# Altium schematic sheet Main.SchDoc
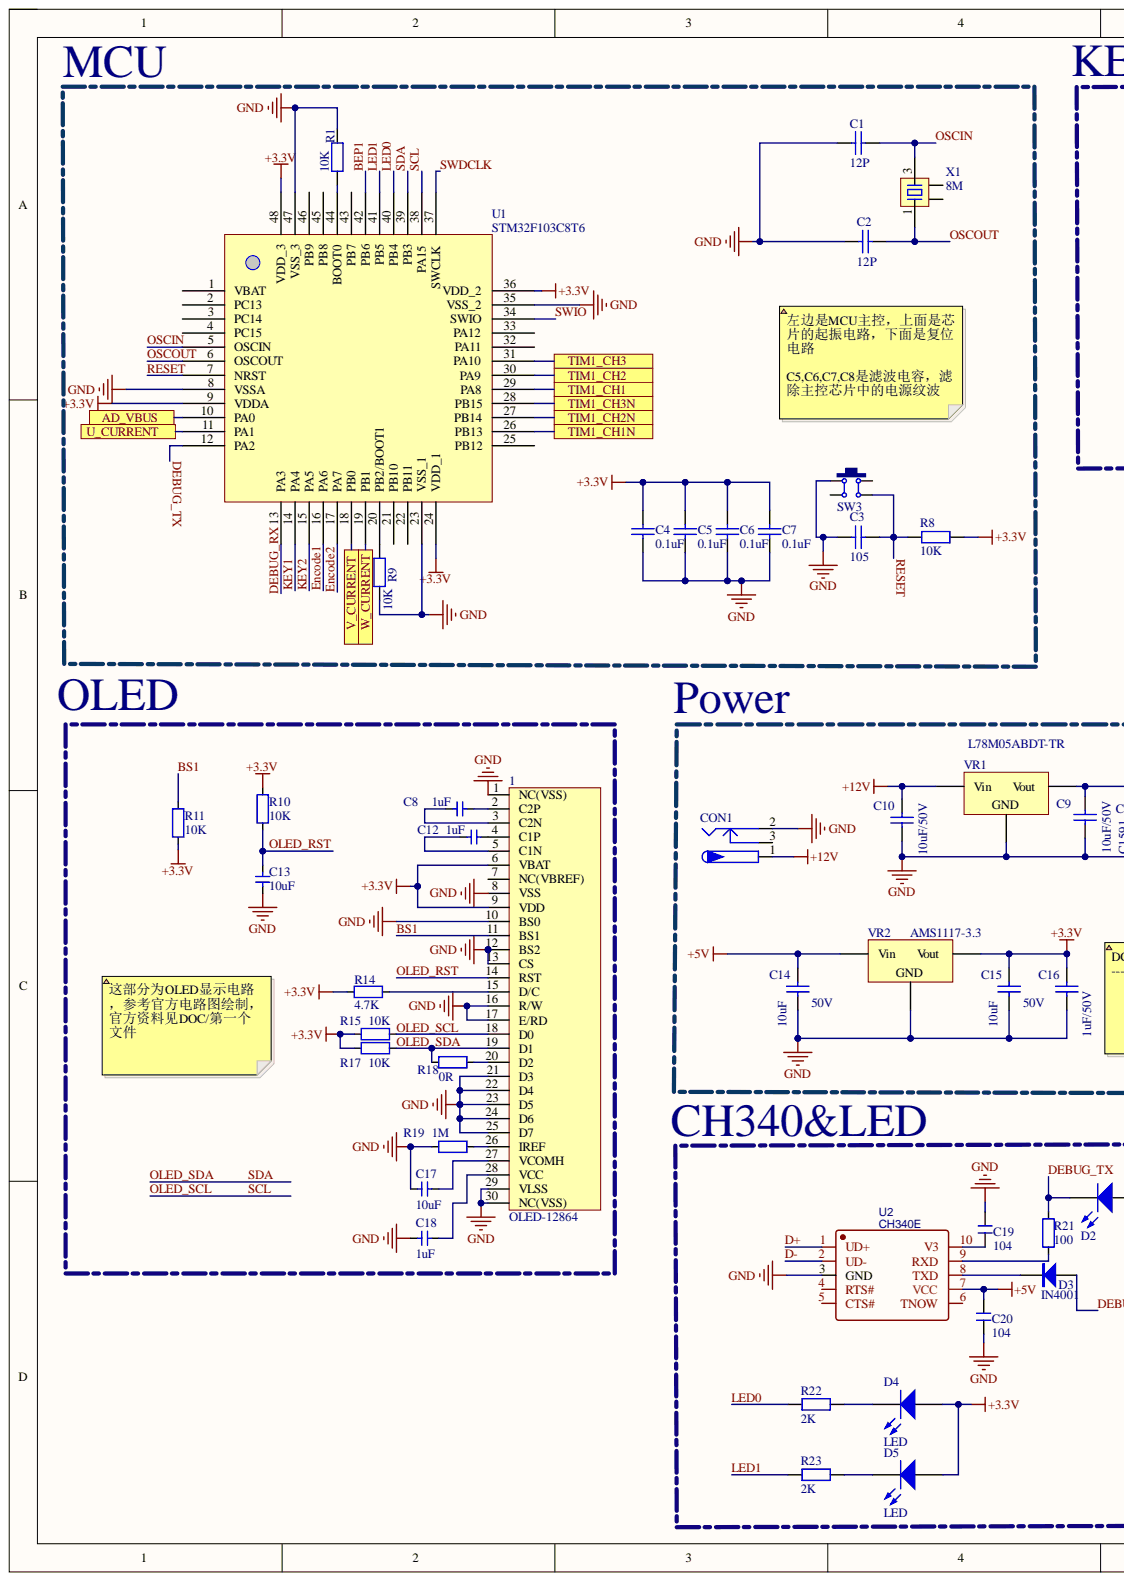
[diagram p0: Main.SchDoc, left]
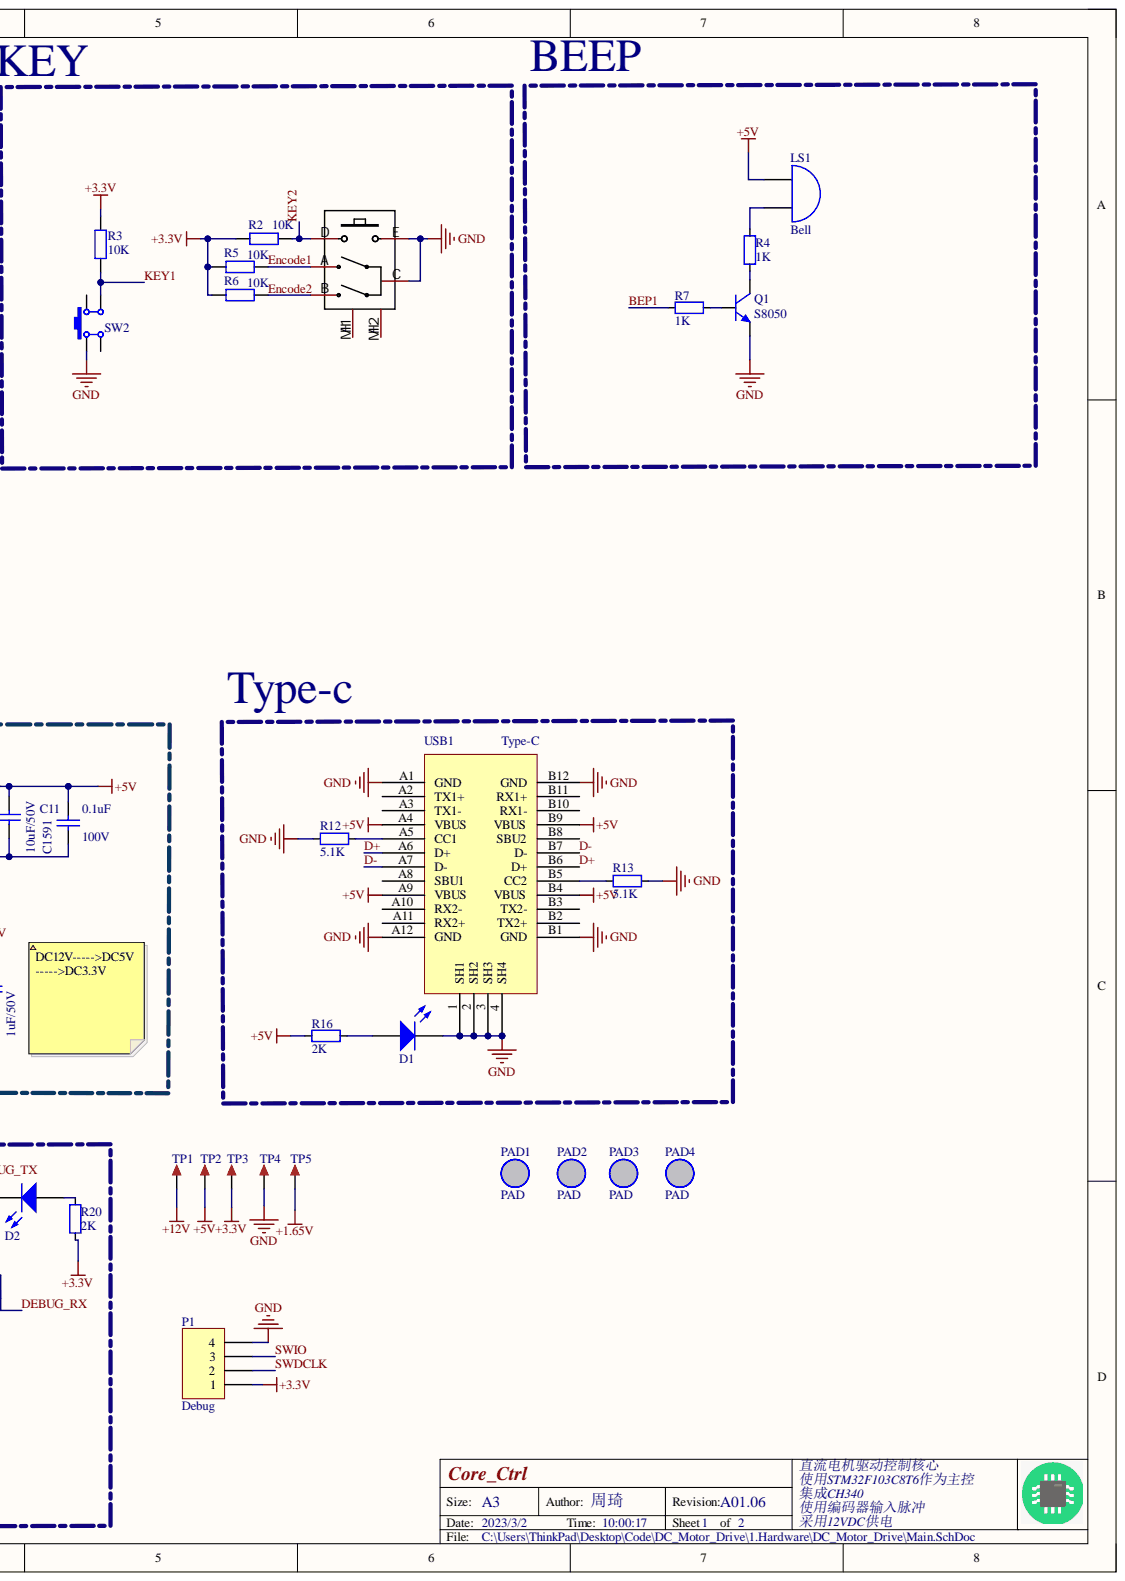
[diagram p1: Main.SchDoc, right]

## Parts
1  OLED-12864  [OLED-12864-1.3-TOP]
C1  12P  [C0603_L]
C2  12P  [C0603_L]
C3  105  [C0402_L]
C4  0.1uF  [C0402_L]
C5  0.1uF  [C0402_L]
C6  0.1uF  [C0402_L]
C7  0.1uF  [C0402_L]
C8  1uF  [C0402_L]
C9  10uF/50V  [C 1206]
C10  10uF/50V  [C 1206]
C11  0.1uF  LC: C1591  parm: 100V  [C 0603]
C12  1uF  [C0402_L]
C13  10uF  [C0402_L]
C14  10uF  parm: 50V  [C 1206]
C15  10uF  parm: 50V  [C 1206]
C16  1uF/50V  [C 1206]
C17  10uF  [C0402_L]
C18  1uF  [C0402_L]
C19  104  [C0402_L]
C20  104  [C0402_L]
CON1  3.5*1.3  [DC-005]  (value not drawn)
D1  LED0  [LED0603_R]  (value not drawn)
D2  LED0  [LED0603_G]  (value not drawn)
D3  IN4001  [SOD-323]
D4  LED  [LED0603_B]
D5  LED  [LED0603_B]
LS1  Bell  [BEEP 5x9x5.5]
P1  Debug  [HDR1X4]
PAD1  PAD  [M2]
PAD2  PAD  [M2]
PAD3  PAD  [M2]
PAD4  PAD  [M2]
Q1  S8050  [SOT23-3N]
R1  10K  [R0402_L]
R2  10K  [R0402_L]
R3  10K  [R0402_L]
R4  1K  [R0402_L]
R5  10K  [R0402_L]
R6  10K  [R0402_L]
R7  1K  [R0402_L]
R8  10K  [R0402_L]
R9  10K  [R0402_L]
R10  10K  [R0402_L]
R11  10K  [R0402_L]
R12  5.1K  [R0402_L]
R13  5.1K  [R0402_L]
R14  4.7K  [R0402_L]
R15  10K  [R0402_L]
R16  2K  [R0402_L]
R17  10K  [R0402_L]
R18  0R  [R0402_L]
R19  1M  [R0402_L]
R20  2K  [R0402_L]
R21  100  [R0402_L]
R22  2K  [R0402_L]
R23  2K  [R0402_L]
SW1  EC11K1524406  [SW-TH_EC11K1524406]  (value not drawn)
SW2  12x12x5  [SW DIP-12*12*4.3]  (value not drawn)
SW3  6x6x5  [TSW SMD-4*4*1.5]  (value not drawn)
TP1  TEST  [TEST 1.6]  (value not drawn)
TP2  TEST  [TEST 1.6]  (value not drawn)
TP3  TEST  [TEST 1.6]  (value not drawn)
TP4  TEST  [TEST 1.6]  (value not drawn)
TP5  TEST  [TEST 1.6]  (value not drawn)
U1  STM32F103C8T6  [LQFP48-7*7]
U2  CH340E  [MSOP10_M]
USB1  Type-C  [USB-C-SMD_16P]
VR1  L78M05ABDT-TR  [TO-252-2-180]
VR2  AMS1117-3.3  [SOT-223]
X1  8M  [OSC 1612-4P]

## Ports
AD_VBUS, TIM1_CH1, TIM1_CH1N, TIM1_CH2, TIM1_CH2N, TIM1_CH3, TIM1_CH3N, U_CURRENT, V_CURRENT, W_CURRENT

## Nets
+1.65V: TP5.1
+3.3V: 1.6(VBAT) 1.9(VDD) C4.1 C5.1 C6.1 C7.1 C15.2 C16.2 D4.1 D5.1 P1.1 R2.2 R3.2 R5.2 R6.2 R8.2 R10.2 R11.1 R14.2 R15.1 R17.1 R20.1 TP3.1 U1.9(VDDA) U1.24(VDD_1) U1.36(VDD_2) U1.48(VDD_3) VR2.2
+5V: C9.2 C11.2 C14.2 C20.1 LS1.1 R16.1 TP2.1 U2.7(VCC) USB1.A4(VBUS) USB1.A9(VBUS) USB1.B4(VBUS) USB1.B9(VBUS) VR1.3 VR2.3
+12V: C10.2 CON1.1 TP1.1 VR1.1
GND: 1.1(NC(VSS)) 1.8(VSS) 1.10(BS0) 1.12(BS2) 1.13(CS) 1.16(R/W) 1.17(E/RD) 1.21(D3) 1.22(D4) 1.23(D5) 1.24(D6) 1.25(D7) 1.29(VLSS) 1.30(NC(VSS)) C1.1 C2.1 C3.1 C4.2 C5.2 C6.2 C7.2 C9.1 C10.1 C11.1 C13.1 C14.1 C15.1 C16.1 C17.2 C18.2 C19.1 C20.2 CON1.2 D1.2 P1.4 Q1.2 R1.2 R9.1 R12.1 R13.1 R19.1 SW1.C SW1.E SW2.1 SW3.1 TP4.1 U1.8(VSSA) U1.23(VSS_1) U1.35(VSS_2) U1.47(VSS_3) U2.3(GND) USB1.1(SH1) USB1.2(SH2) USB1.3(SH3) USB1.4(SH4) USB1.A1(GND) USB1.A12(GND) USB1.B1(GND) USB1.B12(GND) VR1.2 VR2.1
AD_VBUS: U1.10(PA0) ; port AD_VBUS
BEP1: R7.1 U1.42(PB6)
BS1: 1.11(BS1) R11.2
D+: U2.1(UD+) USB1.A6(D+) USB1.B6(D+)
D-: U2.2(UD-) USB1.A7(D-) USB1.B7(D-)
DEBUG_RX: D3.1 U1.13(PA3)
DEBUG_TX: D2.2 R21.1 U1.12(PA2)
Encode1: R5.1 SW1.A U1.16(PA6)
Encode2: R6.1 SW1.B U1.17(PA7)
KEY1: R3.1 SW2.3 U1.14(PA4)
KEY2: R2.1 SW1.D U1.15(PA5)
LED0: R22.2 U1.40(PB4)
LED1: R23.2 U1.41(PB5)
OLED_RST: 1.14(RST) C13.2 R10.1
OLED_SCL: 1.18(D0) R15.2 U1.38(PA15)
OLED_SDA: 1.19(D1) R17.2 R18.2 U1.39(PB3)
OSCIN: C1.2 U1.5(OSCIN) X1.3
OSCOUT: C2.2 U1.6(OSCOUT) X1.1
RESET: C3.2 R8.1 SW3.3 U1.7(NRST)
SWDCLK: P1.2 U1.37(SWCLK)
SWIO: P1.3 U1.34(SWIO)
TIM1_CH1: U1.29(PA8) ; port TIM1_CH1
TIM1_CH1N: U1.26(PB13) ; port TIM1_CH1N
TIM1_CH2: U1.30(PA9) ; port TIM1_CH2
TIM1_CH2N: U1.27(PB14) ; port TIM1_CH2N
TIM1_CH3: U1.31(PA10) ; port TIM1_CH3
TIM1_CH3N: U1.28(PB15) ; port TIM1_CH3N
U_CURRENT: U1.11(PA1) ; port U_CURRENT
V_CURRENT: U1.18(PB0) ; port V_CURRENT
W_CURRENT: U1.19(PB1) ; port W_CURRENT
Net1_2: 1.2(C2P) C8.1
Net1_3: 1.3(C2N) C8.2
Net1_4: 1.4(C1P) C12.1
Net1_5: 1.5(C1N) C12.2
Net1_15: 1.15(D/C) R14.1
Net1_20: 1.20(D2) R18.1
Net1_26: 1.26(IREF) R19.2
Net1_27: 1.27(VCOMH) C17.1
Net1_28: 1.28(VCC) C18.1
NetC19_2: C19.2 U2.10(V3)
NetD1_1: D1.1 R16.2
NetD2_1: D2.1 R20.2
NetD3_2: D3.2 U2.8(TXD)
NetD4_2: D4.2 R22.1
NetD5_2: D5.2 R23.1
NetLS1_2: LS1.2 R4.2
NetQ1_1: Q1.1 R7.2
NetQ1_3: Q1.3 R4.1
NetR1_1: R1.1 U1.44(BOOT0)
NetR9_2: R9.2 U1.20(PB2/BOOT1)
NetR12_2: R12.2 USB1.A5(CC1)
NetR13_2: R13.2 USB1.B5(CC2)
NetR21_2: R21.2 U2.9(RXD)

Not connected: 1 7(NC(VBREF)); CON1 3; SW1 MH1 MH2; SW2 2 4; SW3 2 4; U1 1(VBAT) 2(PC13) 3(PC14) 4(PC15) 21(PB10) 22(PB11) 25(PB12) 32(PA11) 33(PA12) 43(PB7) 45(PB8) 46(PB9); U2 4(RTS#) 5(CTS#) 6(TNOW); USB1 A2(TX1+) A3(TX1-) A8(SBU1) A10(RX2-) A11(RX2+) B2(TX2+) B3(TX2-) B8(SBU2) B10(RX1-) B11(RX1+); X1 2 4

## Library data of the parts
1 = OLED-12864: 显示屏; Component Kind: Standard; Library Name: MyPcbLib.IntLib
C1-C7 = C: 贴片电容; BOM名称: 无极性贴片电容; 联系卖家，免费查找封装: 唯一出售店铺网址https://shop463601444.taobao.com; Component Kind: Standard; Library Name: 0.1 - 电阻-电容-电感-磁珠-电位器.SchLib
C8 C12 C13 C17 C18 = 电容-0402: Capacitor; Value: 0.1u; Component Kind: Standard; Library Name: MyPcbLib.IntLib
C9 C10 C16 = Cap: C89632; LC: C1591; parm: 100V; Component Kind: Standard; Library Name: Miscellaneous Devices.IntLib
C11 = Cap: Component Kind: Standard; Library Name: Miscellaneous Devices.IntLib
C14 C15 = Cap: LC: C1591; Component Kind: Standard; Library Name: Miscellaneous Devices.IntLib
C19 C20 = C: 无极性贴片电容; 版权归属: 源创客; BOM名称: 无极性贴片电容; Component Kind: Standard; Library Name: 9-电阻-电容-电感.SchLib; 学习资源: www.ooocke.com
CON1 = P-DC: 低压电源接口; BOM名称: DC电源接口; 联系卖家，免费技术支持: 唯一出售店铺网址https://shop463601444.taobao.com; Component Kind: Standard; Library Name: 0.8 - 以太网-音频-Type-C USB插座.SchLib
D1 D2 = LED0: 发光二极管; Component Kind: Standard; LatestRevisionDate: 08-Jul-2005; LatestRevisionNote: Mech Layer 1 removed.; PackageReference: LED-0; Published: 8-Jun-2000; Library Name: 18-常用器件.SchLib; Publisher: Altium Limited
D3 = M7: 整流二极管; 版权归属: 源创客; BOM名称: 整流二极管; Component Kind: Standard; Library Name: 10-二极管-整流桥.SchLib; 学习资源: www.ooocke.com
D4 D5 = LED: 发光二极管; 封装库来自淘宝卖家 ID：黄晶宝石: 其他店铺购入均为假冒，请立即申请退款，本店奖励现金5~20元; Component Kind: Standard; Library Name: 0.3 - LED发光二极管.SchLib
LS1 = Bell: Electrical Bell; Component Kind: Standard; LatestRevisionDate: 17-Jul-2002; LatestRevisionNote: Re-released for DXP Platform.; Library Name: Miscellaneous Devices.IntLib; PackageReference: PIN2; Published: 24-Mar-1999; Publisher: Altium Limited
P1 = Header 4: Header, 4-Pin; Component Kind: Standard; LatestRevisionDate: 17-Jul-2002; LatestRevisionNote: Re-released for DXP Platform.; Library Name: Miscellaneous Connectors.IntLib; Signal Integrity: Connector; Publisher: Altium Limited
PAD1-PAD4 = PAD: M2通孔; Component Kind: Standard; Library Name: 原理图库.SchLib
Q1 = 8050-SMD: 高频放大-NPN型; 参数: 40V 1.5A 1W 100MHZ; 封装库来自淘宝卖家 ID：黄晶宝石: 其他店铺购入均为盗版，不享受售后技术支持服务，请立即申请退款; 联系卖家，免费查找封装: 唯一出售店铺网址https://shop463601444.taobao.com; BOM名称: 高频放大-NPN型; Component Kind: Standard; Library Name: 0.4 - 三极管-场效应管-可控硅.SchLib
R1-R3 R5 R6 R8 R9 R22 R23 = R: 贴片电阻; 封装库来自淘宝卖家ID：黄晶宝石: 其他店铺购入均为盗版假冒封装库，请立即申请退款; 联系卖家，免费查找封装: 唯一出售店铺网址https://shop463601444.taobao.com; BOM名称: 贴片电阻; Component Kind: Standard; Library Name: 0.1 - 电阻-电容-电感-磁珠-电位器.SchLib
R4 R7 R10-R21 = 电阻-0402: Resistor; Value: 22R; Component Kind: Standard; Library Name: MyPcbLib.IntLib
SW1 = EC11K1524406: Manufacturer: ALPSALPINE(阿尔卑斯阿尔派); Add into BOM: yes; Component Kind: Standard; Convert to PCB: yes; Datasheet: https://atta.szlcsc.com/upload/public/pdf/source/20190620/C398934_DDD5BF6D843226194F24C74B1929B82F.pdf; Device: EC11K1524406; JLCPCB Part Class: Extended Part; LCSC Part Name: 编码器; Manufacturer Part: EC11K1524406; Name: EC11K1524406; PCB3D: SW-TH_EC11K1524406-L11.7-W12.0-H24.5-P2.5-LS13.1; Supplier: LCSC; Supplier Footprint: 插件; Supplier Part: C398934; Symbol: EC11K1524406
SW2 = TSW DIP-12x12: 直插12x12轻触开关; 联系卖家，免费查找封装: 唯一出售店铺网址https://shop463601444.taobao.com; BOM名称: 直插12x12轻触开关; Component Kind: Standard; Library Name: 0.7 - 常用按键-开关-蜂鸣器-电池-测试点.SchLib
SW3 = TSW SMD-6x6: 贴片6x6轻触开关; 版权归属: 源创客; BOM名称: 贴片6x6轻触开关; Component Kind: Standard; Library Name: 5-按键-开关.SchLib; 学习资源: www.ooocke.com
TP1-TP5 = TEST: 测试点; 联系卖家，免费查找封装: 唯一出售店铺网址https://shop463601444.taobao.com; BOM名称: 测试点; Component Kind: Standard; Library Name: 0.7 - 常用按键-开关-蜂鸣器-电池-测试点.SchLib
U2 = CH340E: BOM_Manufacturer: WCH; subpart_no: 1; BOM_JLCPCB Part Class: Extended Part; BOM_Manufacturer Part: CH340E; BOM_Supplier: LCSC; BOM_Supplier Part: C99652; Component Kind: Standard; spicePre: U; spiceSymbolName: CH340E
USB1 = USB-Type-C-TOP: Component Kind: Standard; Library Name: MyPcbLib.IntLib
VR1 = TO-252-2 L78M05ABDT-TR: 低压差线性稳压(LDO); Component Kind: Standard; ComponentLink1Description: 供应商链接; ComponentLink1URL: http://www.szlcsc.com/product/details_59107.html; Library Name: LDO.SchLib; Package: TO-252-2; Supplier: LC; SuppliersPartNumber: C58069
VR2 = SOT-223 AMS1117-3.3: 低压差线性稳压(LDO); Component Kind: Standard; ComponentLink1Description: 供应商链接; ComponentLink1URL: http://www.szlcsc.com/product/details_6652.html; Library Name: Miscellaneous Devices LC.IntLib; Package: SOT-223; Supplier: LC; SuppliersPartNumber: C6186
X1 = XTAL-4P: 4脚无源晶振; 联系卖家，免费查找封装: 唯一出售店铺网址https://shop463601444.taobao.com; BOM名称: 4脚无源晶振; Component Kind: Standard; Library Name: 0.5 - 保险丝-晶振-光耦.SchLib
U1 = STM32F103C8T6: Component Kind: Standard; Library Name: STM32F103C8T6.SCHLIB
